# Altium schematic sheet JacdacBreadboardEc30-16x6 128.SchDoc
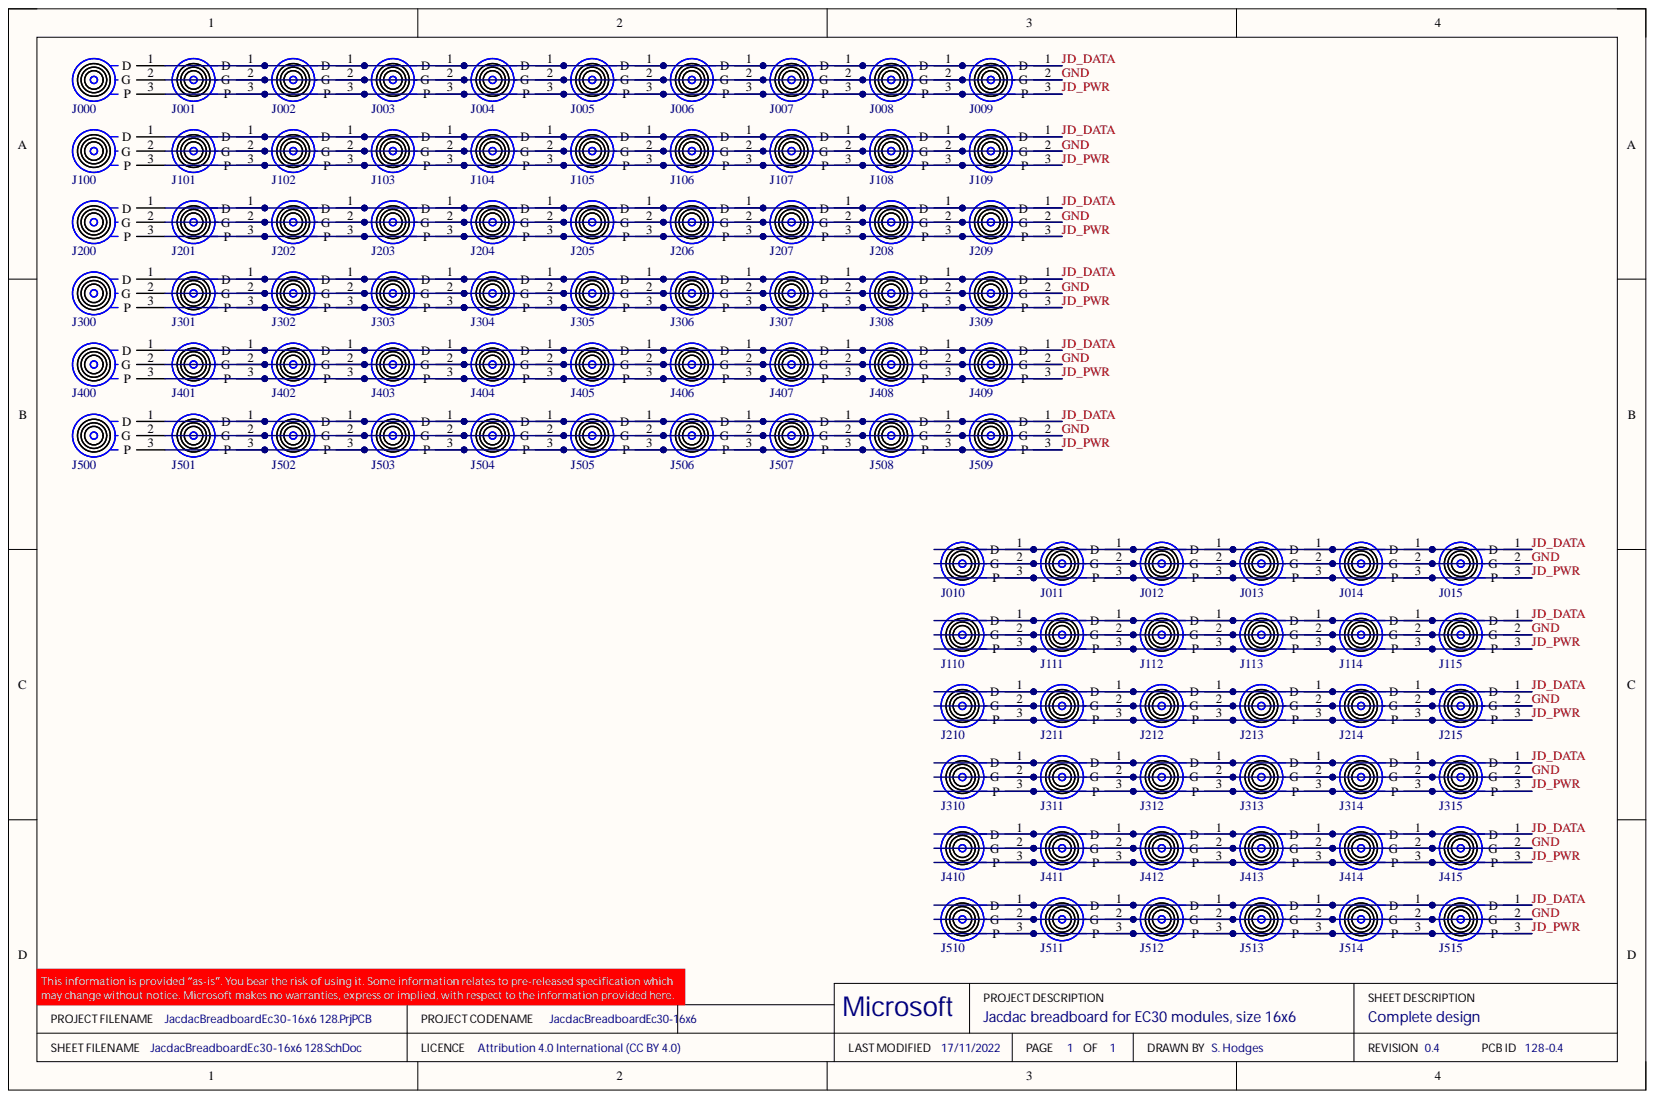
[diagram p0: JacdacBreadboardEc30-16x6 128.SchDoc]

## Parts
J000  (no value)
J001  (no value)
J002  (no value)
J003  (no value)
J004  (no value)
J005  (no value)
J006  (no value)
J007  (no value)
J008  (no value)
J009  (no value)
J010  (no value)
J011  (no value)
J012  (no value)
J013  (no value)
J014  (no value)
J015  (no value)
J100  (no value)
J101  (no value)
J102  (no value)
J103  (no value)
J104  (no value)
J105  (no value)
J106  (no value)
J107  (no value)
J108  (no value)
J109  (no value)
J110  (no value)
J111  (no value)
J112  (no value)
J113  (no value)
J114  (no value)
J115  (no value)
J200  (no value)
J201  (no value)
J202  (no value)
J203  (no value)
J204  (no value)
J205  (no value)
J206  (no value)
J207  (no value)
J208  (no value)
J209  (no value)
J210  (no value)
J211  (no value)
J212  (no value)
J213  (no value)
J214  (no value)
J215  (no value)
J300  (no value)
J301  (no value)
J302  (no value)
J303  (no value)
J304  (no value)
J305  (no value)
J306  (no value)
J307  (no value)
J308  (no value)
J309  (no value)
J310  (no value)
J311  (no value)
J312  (no value)
J313  (no value)
J314  (no value)
J315  (no value)
J400  (no value)
J401  (no value)
J402  (no value)
J403  (no value)
J404  (no value)
J405  (no value)
J406  (no value)
J407  (no value)
J408  (no value)
J409  (no value)
J410  (no value)
J411  (no value)
J412  (no value)
J413  (no value)
J414  (no value)
J415  (no value)
J500  (no value)
J501  (no value)
J502  (no value)
J503  (no value)
J504  (no value)
J505  (no value)
J506  (no value)
J507  (no value)
J508  (no value)
J509  (no value)
J510  (no value)
J511  (no value)
J512  (no value)
J513  (no value)
J514  (no value)
J515  (no value)

## Nets
GND: J000.2 J001.2 J002.2 J003.2 J004.2 J005.2 J006.2 J007.2 J008.2 J009.2 J010.2 J011.2 J012.2 J013.2 J014.2 J015.2 J100.2 J101.2 J102.2 J103.2 J104.2 J105.2 J106.2 J107.2 J108.2 J109.2 J110.2 J111.2 J112.2 J113.2 J114.2 J115.2 J200.2 J201.2 J202.2 J203.2 J204.2 J205.2 J206.2 J207.2 J208.2 J209.2 J210.2 J211.2 J212.2 J213.2 J214.2 J215.2 J300.2 J301.2 J302.2 J303.2 J304.2 J305.2 J306.2 J307.2 J308.2 J309.2 J310.2 J311.2 J312.2 J313.2 J314.2 J315.2 J400.2 J401.2 J402.2 J403.2 J404.2 J405.2 J406.2 J407.2 J408.2 J409.2 J410.2 J411.2 J412.2 J413.2 J414.2 J415.2 J500.2 J501.2 J502.2 J503.2 J504.2 J505.2 J506.2 J507.2 J508.2 J509.2 J510.2 J511.2 J512.2 J513.2 J514.2 J515.2
JD_DATA: J000.1 J001.1 J002.1 J003.1 J004.1 J005.1 J006.1 J007.1 J008.1 J009.1 J010.1 J011.1 J012.1 J013.1 J014.1 J015.1 J100.1 J101.1 J102.1 J103.1 J104.1 J105.1 J106.1 J107.1 J108.1 J109.1 J110.1 J111.1 J112.1 J113.1 J114.1 J115.1 J200.1 J201.1 J202.1 J203.1 J204.1 J205.1 J206.1 J207.1 J208.1 J209.1 J210.1 J211.1 J212.1 J213.1 J214.1 J215.1 J300.1 J301.1 J302.1 J303.1 J304.1 J305.1 J306.1 J307.1 J308.1 J309.1 J310.1 J311.1 J312.1 J313.1 J314.1 J315.1 J400.1 J401.1 J402.1 J403.1 J404.1 J405.1 J406.1 J407.1 J408.1 J409.1 J410.1 J411.1 J412.1 J413.1 J414.1 J415.1 J500.1 J501.1 J502.1 J503.1 J504.1 J505.1 J506.1 J507.1 J508.1 J509.1 J510.1 J511.1 J512.1 J513.1 J514.1 J515.1
JD_PWR: J000.3 J001.3 J002.3 J003.3 J004.3 J005.3 J006.3 J007.3 J008.3 J009.3 J010.3 J011.3 J012.3 J013.3 J014.3 J015.3 J100.3 J101.3 J102.3 J103.3 J104.3 J105.3 J106.3 J107.3 J108.3 J109.3 J110.3 J111.3 J112.3 J113.3 J114.3 J115.3 J200.3 J201.3 J202.3 J203.3 J204.3 J205.3 J206.3 J207.3 J208.3 J209.3 J210.3 J211.3 J212.3 J213.3 J214.3 J215.3 J300.3 J301.3 J302.3 J303.3 J304.3 J305.3 J306.3 J307.3 J308.3 J309.3 J310.3 J311.3 J312.3 J313.3 J314.3 J315.3 J400.3 J401.3 J402.3 J403.3 J404.3 J405.3 J406.3 J407.3 J408.3 J409.3 J410.3 J411.3 J412.3 J413.3 J414.3 J415.3 J500.3 J501.3 J502.3 J503.3 J504.3 J505.3 J506.3 J507.3 J508.3 J509.3 J510.3 J511.3 J512.3 J513.3 J514.3 J515.3

## Library data of the parts
J000 J001 J002 J003 J004 J005 J006 J007 J008 J009 J010 J011 J012 J013 J014 J015 J100-J115 J200-J215 J300-J315 J400-J415 J500-J515 = (no symbol name): Hole Clearance: 7.0mm; Hole Size: M3
